# Altium schematic sheet bugclk.SchDoc
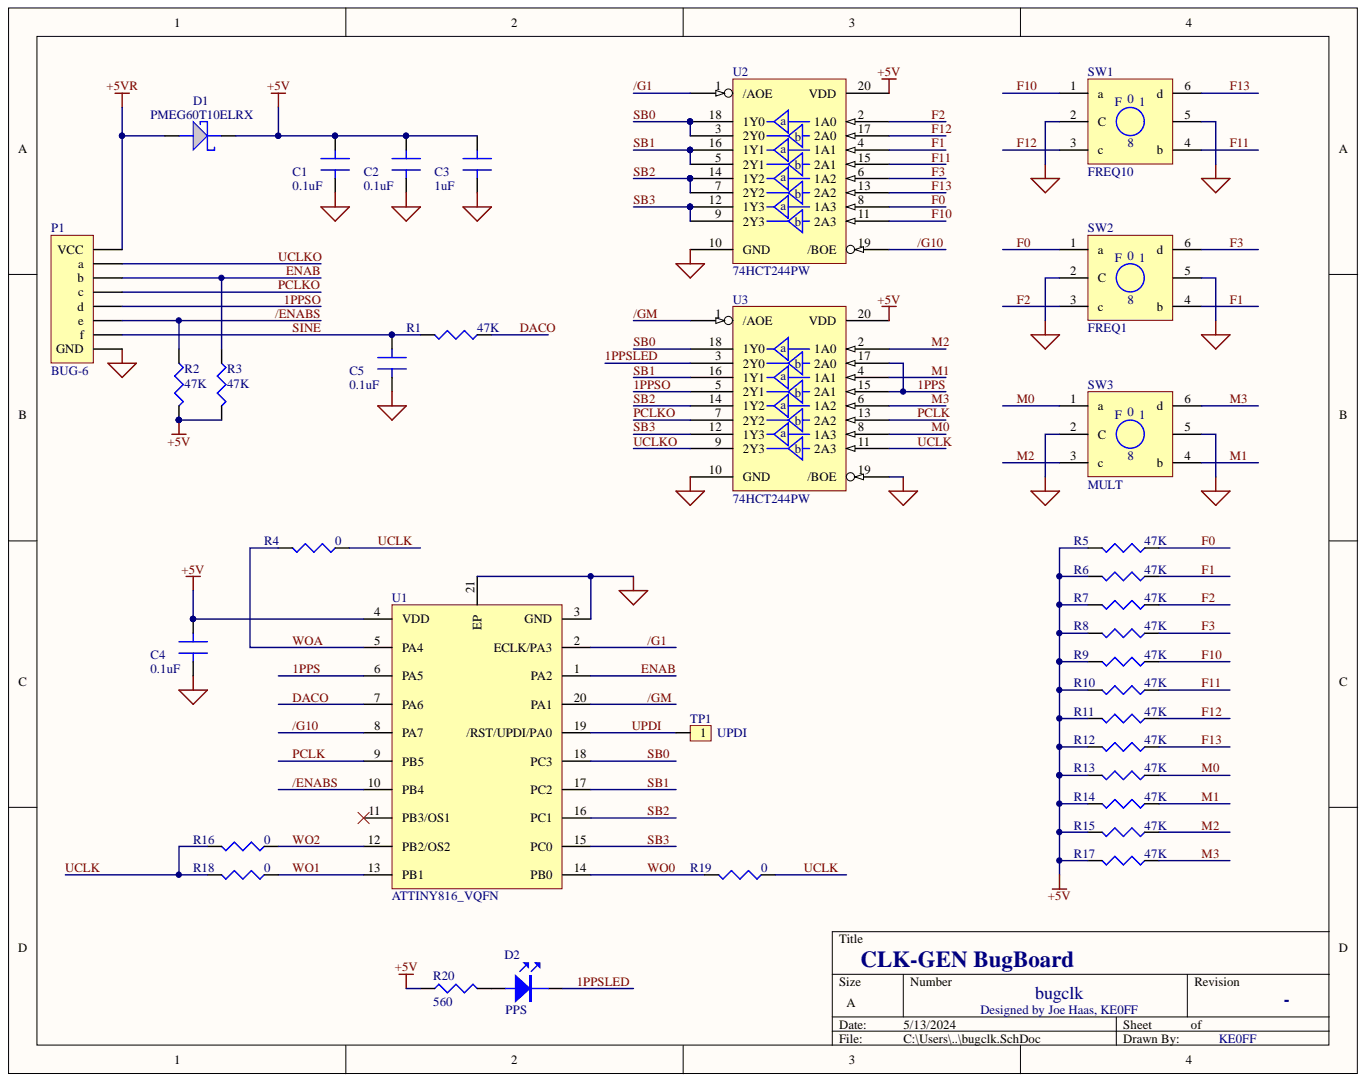
[diagram p0: bugclk.SchDoc]

## Parts
C1  0.1uF  [0402]
C2  0.1uF  [0402]
C3  1uF  [0805]
C4  0.1uF  [0402]
C5  0.1uF  [0805]
D1  PMEG60T10ELRX  [SOD123]
D2  PPS  [0805-LED]
P1  BUG-6  [BUG-6]
R1  47K  [0402]
R2  47K  [0402]
R3  47K  [0402]
R4  0  [0402]
R5  47K  [0402]
R6  47K  [0402]
R7  47K  [0402]
R8  47K  [0402]
R9  47K  [0402]
R10  47K  [0402]
R11  47K  [0402]
R12  47K  [0402]
R13  47K  [0402]
R14  47K  [0402]
R15  47K  [0402]
R16  0  [0402]
R17  47K  [0402]
R18  0  [0402]
R19  0  [0402]
R20  560  [0402]
SW1  FREQ10  [ROT_SW_DIP_TH]
SW2  FREQ1  [ROT_SW_DIP_TH]
SW3  MULT  [ROT_SW_DIP_TH]
TP1  UPDI  [TP-SMD-20x50-SQ]  (no BOM)
U1  ATTINY816_VQFN  [VQFN20_3X3_SM]
U2  74HCT244PW  [TSSOP-20-HC244]
U3  74HCT244PW  [TSSOP-20-HC244]

## Nets
+5V: C1.1 C2.1 C3.1 C4.1 D1.1 R2.1 R3.1 R5.1 R6.1 R7.1 R8.1 R9.1 R10.1 R11.1 R12.1 R13.1 R14.1 R15.1 R17.1 R20.2 U1.4(VDD) U2.20(VDD) U3.20(VDD)
+5VR: D1.2 P1.8(VCC)
GND: C1.2 C2.2 C3.2 C4.2 C5.2 P1.7(GND) SW1.2(C) SW1.5 SW2.2(C) SW2.5 SW3.2(C) SW3.5 U1.3(GND) U1.21(EP) U2.10(GND) U3.10(GND) U3.19(/BOE)
1PPS: U1.6(PA5) U3.15(2A1) U3.17(2A0)
1PPSLED: D2.1 U3.3(2Y0)
1PPSO: P1.4(d) U3.5(2Y1)
/ENABS: P1.5(e) R2.2 U1.10(PB4)
/G1: U1.2(ECLK/PA3) U2.1(/AOE)
/G10: U1.8(PA7) U2.19(/BOE)
/GM: U1.20(PA1) U3.1(/AOE)
DACO: R1.2 U1.7(PA6)
ENAB: P1.2(b) R3.2 U1.1(PA2)
F0: R5.2 SW2.1(a) U2.8(1A3)
F1: R6.2 SW2.4(b) U2.4(1A1)
F2: R7.2 SW2.3(c) U2.2(1A0)
F3: R8.2 SW2.6(d) U2.6(1A2)
F10: R9.2 SW1.1(a) U2.11(2A3)
F11: R10.2 SW1.4(b) U2.15(2A1)
F12: R11.2 SW1.3(c) U2.17(2A0)
F13: R12.2 SW1.6(d) U2.13(2A2)
M0: R13.2 SW3.1(a) U3.8(1A3)
M1: R14.2 SW3.4(b) U3.4(1A1)
M2: R15.2 SW3.3(c) U3.2(1A0)
M3: R17.2 SW3.6(d) U3.6(1A2)
PCLK: U1.9(PB5) U3.13(2A2)
PCLKO: P1.3(c) U3.7(2Y2)
SB0: U1.18(PC3) U2.3(2Y0) U2.18(1Y0) U3.18(1Y0)
SB1: U1.17(PC2) U2.5(2Y1) U2.16(1Y1) U3.16(1Y1)
SB2: U1.16(PC1) U2.7(2Y2) U2.14(1Y2) U3.14(1Y2)
SB3: U1.15(PC0) U2.9(2Y3) U2.12(1Y3) U3.12(1Y3)
SINE: C5.1 P1.6(f) R1.1
UCLK: R4.2 R16.1 R18.1 R19.2 U3.11(2A3)
UCLKO: P1.1(a) U3.9(2Y3)
UPDI: TP1.1 U1.19(/RST/UPDI/PA0)
WO0: R19.1 U1.14(PB0)
WO1: R18.2 U1.13(PB1)
WO2: R16.2 U1.12(PB2/OS2)
WOA: R4.1 U1.5(PA4)
NetD2_2: D2.2 R20.1

Not connected: U1 11(PB3/OS1)

## Library data of the parts
C1-C5 = CAP CER: CAP, CER, 0805, 0.1uF, 50V; Component Kind: Standard; MFR: ?; MPN: ?
D1 = DIODE_SCHOTTKY_SOD123: DIODE, SHTKY; MFR: Nexperia; ALT-MPN: -; Component Kind: Standard; DNP: -; MPN: PMEG60T10ELRX; NOTES: -; NOTES2: -; PRI-MPN: -; Library Name: C:\Users\ke0ff\Documents\Altium\GalaxyLibs-jmh_1.08\Galaxy PCB-jem-1.08.PcbLib
D2 = LED: LED, SMD; ALT-MPN: -; Component Kind: Standard; DNP: -; MFR: -; MPN: -; NOTES: -; NOTES2: -; PRI-MPN: -; Library Name: Galaxy SCH-jem-1.08.SchLib
P1 = BUG-6_PWR: CON, BUG-BD, 6pos+pwr; NOTES: Uses hand-cut wire pins; ALT-MPN: -; Component Kind: Standard; DNP: -; MFR: N/A; MPN: N/A; NOTES2: -; PRI-MPN: -; Library Name: Galaxy SCH-jem-1.08.SchLib
R1-R3 R5-R15 R17 = R0805: RES, CHP, 0402, 1%, 47K; MFR: Yageo; MPN: RC0402FR-0747KL; Component Kind: Standard; Library Name: C:\Users\ke0ff\Documents\Altium\GalaxyLibs-jmh_1.08\Galaxy PCB-jem-1.08.PcbLib
R4 R16 R18 R19 = R0805: RES, CHP, 0402, 1%, 0; MFR: Yageo; MPN: RC0402FR-070RL; Component Kind: Standard; Library Name: C:\Users\ke0ff\Documents\Altium\GalaxyLibs-jmh_1.08\Galaxy PCB-jem-1.08.PcbLib
R20 = R0805: RES, CHP, 0402, 1%, 560; MFR: Yageo; MPN: RC0402FR-07560RL; Component Kind: Standard; Library Name: C:\Users\ke0ff\Documents\Altium\GalaxyLibs-jmh_1.08\Galaxy PCB-jem-1.08.PcbLib
SW1-SW3 = SW_ROT_16: SW, ROT, HEX, 16pos; ALT-MPN: -; Component Kind: Standard; DNP: -; MFR: -; MPN: -; NOTES: -; NOTES2: -; PRI-MPN: -; Library Name: Galaxy SCH-jem-1.08.SchLib
TP1 = TP: MECH, TEST POINT; ALT-MPN: -; DNP: -; MFR: -; MPN: -; NOTES: -; NOTES2: -; PRI-MPN: -; Library Name: Galaxy SCH-jem-1.08.SchLib
U1 = ATTINY816_VQFN: IC, MCU, SFF, 8b, 8Kb, 20MHz; MFR: Microchip; MPN: ATTINY816-MN; ALT-MPN: -; Component Kind: Standard; DNP: -; NOTES: -; NOTES2: -; PRI-MPN: -; Library Name: Galaxy SCH-jem-1.08.SchLib
U2 U3 = 74HC244: IC, LGC, BUF, OCTAL, 3-STATE; MFR: Nexperia; MPN: 74VHCT244PW,118; ALT-MPN: -; Component Kind: Standard; DNP: -; NOTES: -; NOTES2: -; PRI-MPN: -; Library Name: Galaxy SCH-jem-1.08.SchLib
